# Altium schematic sheet BuckAndOutputCircuits. Page 2.SchDoc
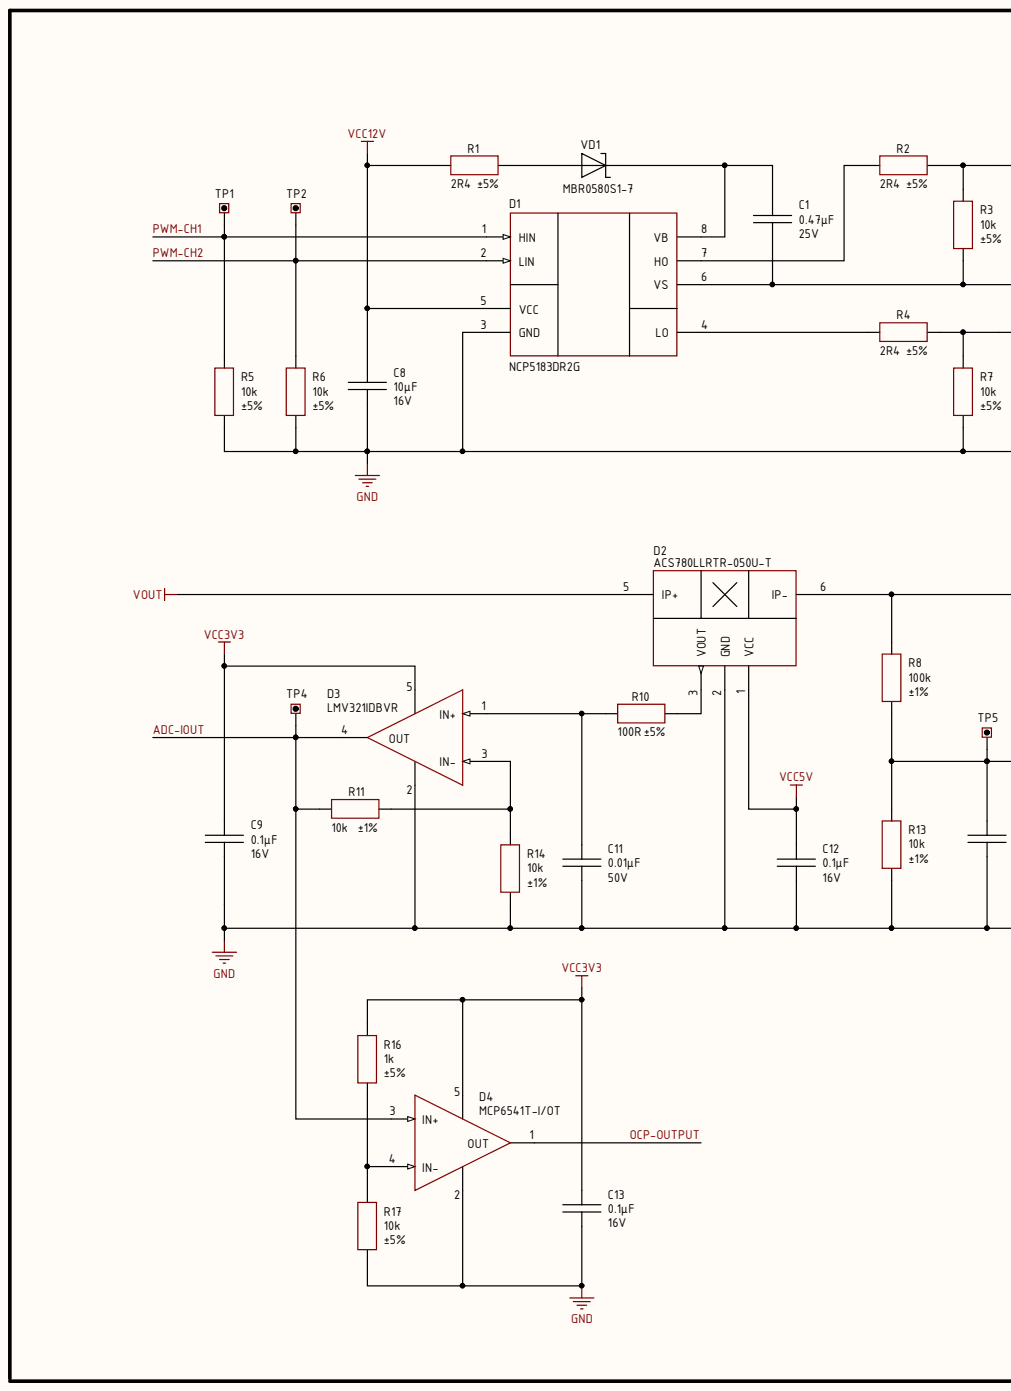
[diagram p0: BuckAndOutputCircuits. Page 2.SchDoc, left]
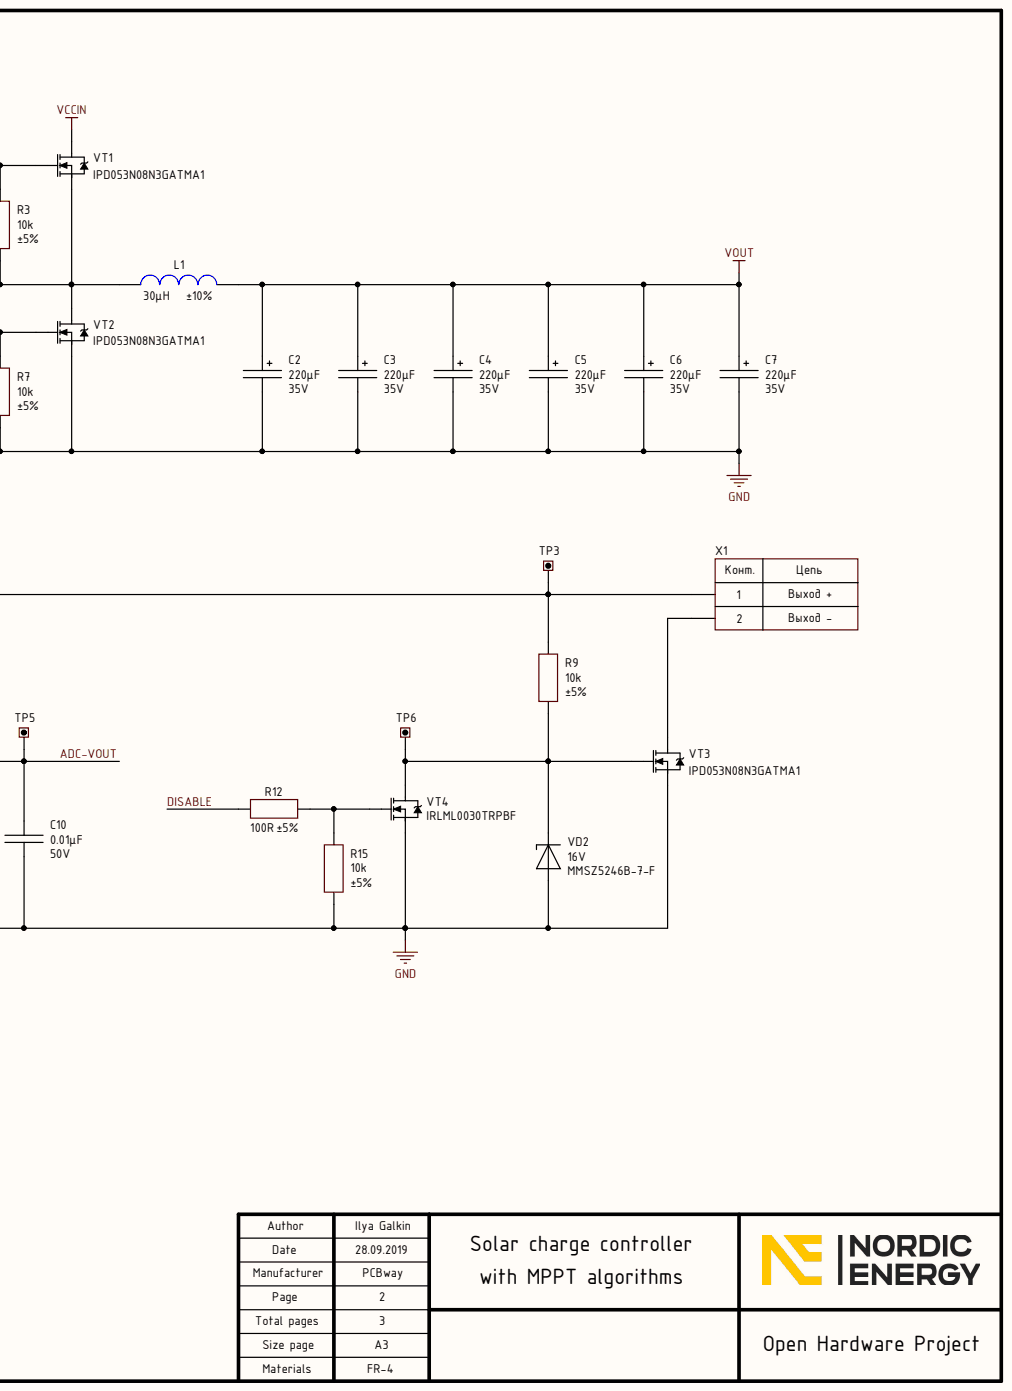
[diagram p1: BuckAndOutputCircuits. Page 2.SchDoc, right]

## Parts
C1  value 0.47μF  Voltage: 25V  [CAP: 0805]  (hidden comment: Ceramic capacitor, 0.47μF, X7R, ±10%, 25V, 0805, -55...+125°C)
C2  value 220μF  Voltage: 35V  [CAP: D6.3C2.5H11]  (hidden comment: Aluminium polymer capacitor, 220μF, 35V, ±20%, 30mOhm, 12x6.3x2.5 mm, -55...+105°C)
C3  value 220μF  Voltage: 35V  [CAP: D6.3C2.5H11]  (hidden comment: Aluminium polymer capacitor, 220μF, 35V, ±20%, 30mOhm, 12x6.3x2.5 mm, -55...+105°C)
C4  value 220μF  Voltage: 35V  [CAP: D6.3C2.5H11]  (hidden comment: Aluminium polymer capacitor, 220μF, 35V, ±20%, 30mOhm, 12x6.3x2.5 mm, -55...+105°C)
C5  value 220μF  Voltage: 35V  [CAP: D6.3C2.5H11]  (hidden comment: Aluminium polymer capacitor, 220μF, 35V, ±20%, 30mOhm, 12x6.3x2.5 mm, -55...+105°C)
C6  value 220μF  Voltage: 35V  [CAP: D6.3C2.5H11]  (hidden comment: Aluminium polymer capacitor, 220μF, 35V, ±20%, 30mOhm, 12x6.3x2.5 mm, -55...+105°C)
C7  value 220μF  Voltage: 35V  [CAP: D6.3C2.5H11]  (hidden comment: Aluminium polymer capacitor, 220μF, 35V, ±20%, 30mOhm, 12x6.3x2.5 mm, -55...+105°C)
C8  value 10μF  Voltage: 16V  [CAP: 0805]  (hidden comment: Ceramic capacitor, 10μF, X7R, ±10%, 16V, 0805, -55...+125°C)
C9  value 0.1μF  Voltage: 16V  [CAP: 0805]  (hidden comment: Ceramic capacitor, 0.1μF, X7R, ±10%, 16V, 0805, -55...+125°C)
C10  value 0.01μF  Voltage: 50V  [CAP: 0805]  (hidden comment: Ceramic capacitor, 0.01μF, X7R, ±10%, 50V, 0805, -55...+125°C)
C11  value 0.01μF  Voltage: 50V  [CAP: 0805]  (hidden comment: Ceramic capacitor, 0.01μF, X7R, ±10%, 50V, 0805, -55...+125°C)
C12  value 0.1μF  Voltage: 16V  [CAP: 0805]  (hidden comment: Ceramic capacitor, 0.1μF, X7R, ±10%, 16V, 0805, -55...+125°C)
C13  value 0.1μF  Voltage: 16V  [CAP: 0805]  (hidden comment: Ceramic capacitor, 0.1μF, X7R, ±10%, 16V, 0805, -55...+125°C)
D1  Half-bridge gate driver, 600V, 500kHz, ±4.3A, supply 9...18V, -40...+125°C, 8-SOIC  [8-SOIC]  (value not drawn)
D2  PartNumber: ACS780LLRTR-050U-T  [SENSOR: ACS781]  (hidden comment: IC current sensors, 50A, ±1.5%, 120 kHz, 60mV/A, -40...+150°C, 7-PSOF)
D3  IC op amplifier, Rail-to-Rail, 1 MHz, 2.7...5.5V, -40...+125°C, SOT23-5  [SOT23-5]  (value not drawn)
D4  IC comparator, Rail-to-Rail, 1.6...5.5V, -40...+85°C, SOT23-5  [SOT23-5]  (value not drawn)
L1  value 30μH  Tolerance: ±10%  [IND: R32.8/20.1/10.7 (L)]  (hidden comment: Fixed inductor, 30μH, ±10%, R32.8/20.1/10.7, Kool Mu, µ=125, 127 нГн/витков2)
R1  value 2R4  Tolerance: ±5%  [RES: 0805]  (hidden comment: Resistor film, 2R4, ±5%, 0805, 0.125W, -55...+125°C)
R2  value 2R4  Tolerance: ±5%  [RES: 0805]  (hidden comment: Resistor film, 2R4, ±5%, 0805, 0.125W, -55...+125°C)
R3  value 10k  Tolerance: ±5%  [RES: 0805]  (hidden comment: Resistor film, 10k, ±5%, 0805, 0.125W, -55...+125°C)
R4  value 2R4  Tolerance: ±5%  [RES: 0805]  (hidden comment: Resistor film, 2R4, ±5%, 0805, 0.125W, -55...+125°C)
R5  value 10k  Tolerance: ±5%  [RES: 0805]  (hidden comment: Resistor film, 10k, ±5%, 0805, 0.125W, -55...+125°C)
R6  value 10k  Tolerance: ±5%  [RES: 0805]  (hidden comment: Resistor film, 10k, ±5%, 0805, 0.125W, -55...+125°C)
R7  value 10k  Tolerance: ±5%  [RES: 0805]  (hidden comment: Resistor film, 10k, ±5%, 0805, 0.125W, -55...+125°C)
R8  value 100k  Tolerance: ±1%  [RES: 0805]  (hidden comment: Resistor film, 100k, ±1%, 0805, 0.125W, -55...+125°C)
R9  value 10k  Tolerance: ±5%  [RES: 0805]  (hidden comment: Resistor film, 10k, ±5%, 0805, 0.125W, -55...+125°C)
R10  value 100R  Tolerance: ±5%  [RES: 0805]  (hidden comment: Resistor film, 100R, ±5%, 0805, 0.125W, -55...+125°C)
R11  value 10k  Tolerance: ±1%  [RES: 0805]  (hidden comment: Resistor film, 10k, ±1%, 0805, 0.125W, -55...+125°C)
R12  value 100R  Tolerance: ±5%  [RES: 0805]  (hidden comment: Resistor film, 100R, ±5%, 0805, 0.125W, -55...+125°C)
R13  value 10k  Tolerance: ±1%  [RES: 0805]  (hidden comment: Resistor film, 10k, ±1%, 0805, 0.125W, -55...+125°C)
R14  value 10k  Tolerance: ±1%  [RES: 0805]  (hidden comment: Resistor film, 10k, ±1%, 0805, 0.125W, -55...+125°C)
R15  value 10k  Tolerance: ±5%  [RES: 0805]  (hidden comment: Resistor film, 10k, ±5%, 0805, 0.125W, -55...+125°C)
R16  value 1k  Tolerance: ±5%  [RES: 0805]  (hidden comment: Resistor film, 1k, ±5%, 0805, 0.125W, -55...+125°C)
R17  value 10k  Tolerance: ±5%  [RES: 0805]  (hidden comment: Resistor film, 10k, ±5%, 0805, 0.125W, -55...+125°C)
TP1  Test point, Keystone, 5001  [CON: 5001 (Keystone)]  (value not drawn)
TP2  Test point, Keystone, 5001  [CON: 5001 (Keystone)]  (value not drawn)
TP3  Test point, Keystone, 5001  [CON: 5001 (Keystone)]  (value not drawn)
TP4  Test point, Keystone, 5001  [CON: 5004 (Keystone)]  (value not drawn)
TP5  Test point, Keystone, 5001  [CON: 5004 (Keystone)]  (value not drawn)
TP6  Test point, Keystone, 5001  [CON: 5001 (Keystone)]  (value not drawn)
VD1  PartNumber: MBR0580S1-7  [DIODE: SOD123]  (hidden comment: Schottky diode, 80V, 500mA, 1V, 15pF, SOD-123, -55...+150°C)
VD2  PartNumber: MMSZ5246B-7-F  Voltage: 16V  [DIODE: SOD123]  (hidden comment: Zener diode, 16V, 500mW, 0.9V, 17Ohm, SOD-123, -65...+150°C)
VT1  PartNumber: IPD053N08N3GATMA1  [DPAK]  (hidden comment: MOSFET N-channel transistor, 80V, 90A, 69nC, 150W, 5.3mOhm, 4750pF, Dpak, -55...+150°C)
VT2  PartNumber: IPD053N08N3GATMA1  [DPAK]  (hidden comment: MOSFET N-channel transistor, 80V, 90A, 69nC, 150W, 5.3mOhm, 4750pF, Dpak, -55...+150°C)
VT3  PartNumber: IPD053N08N3GATMA1  [DPAK]  (hidden comment: MOSFET N-channel transistor, 80V, 90A, 69nC, 150W, 5.3mOhm, 4750pF, Dpak, -55...+150°C)
VT4  PartNumber: IRLML0030TRPBF  [SOT23]  (hidden comment: MOSFET N-channel transistor, 30V, 5.3A, 2.6nC, 2.5W, 27mOhm, 382pF, SOT-23, -55...+150°C)
X1  Connector, 2pin, 9.53mm, 300VAC, 25A  [CON: 6PCV-02-006]  (value not drawn)

## Nets
GND: C2.2 C3.2 C4.2 C5.2 C6.2 C7.2 C8.1 C9.1 C10.1 C11.1 C12.1 C13.1 D1.3(GND) D2.2(GND) D3.2 D4.2 R5.2 R6.2 R7.2 R13.2 R14.2 R15.2 R17.2 VD2.1 VT2.2 VT3.2 VT4.2
VCC3V3: C9.2 C13.2 D3.5 D4.5 R16.1
VCC5V: C12.2 D2.1(VCC)
VCC12V: C8.2 D1.5(VCC) R1.1
VCCIN: VT1.3
VOUT: C2.1 C3.1 C4.1 C5.1 C6.1 C7.1 D2.5(IP+) L1.1
ADC-IOUT: D3.4(OUT) D4.3(IN+) R11.1 TP4.1
ADC-VOUT: C10.2 R8.2 R13.1 TP5.1
DISABLE: R12.1
OCP-OUTPUT: D4.1(OUT)
PWM-CH1: D1.1(HIN) R5.1 TP1.1
PWM-CH2: D1.2(LIN) R6.1 TP2.1
NetC1_1: C1.1 D1.6(VS) L1.2 R3.2 VT1.2 VT2.3
NetC1_2: C1.2 D1.8(VB) VD1.2
NetC11_2: C11.2 D3.1(IN+) R10.1
NetD1_4: D1.4(LO) R4.1
NetD1_7: D1.7(HO) R2.1
NetD2_3: D2.3(VOUT) R10.2
NetD2_6: D2.6(IP-) R8.1 R9.1 TP3.1 X1.1
NetD3_3: D3.3(IN-) R11.2 R14.1
NetD4_4: D4.4(IN-) R16.2 R17.1
NetR1_2: R1.2 VD1.1
NetR2_2: R2.2 R3.1 VT1.1
NetR4_2: R4.2 R7.1 VT2.1
NetR9_2: R9.2 TP6.1 VD2.2 VT3.1 VT4.3
NetR12_2: R12.2 R15.1 VT4.1
NetVT3_3: VT3.3 X1.2

## Library data of the parts
C1 = Ceramic_0805: Manufacturer: Yageo; PartNumber: CC0805KKX7R8BB474; Component Kind: Standard; HelpURL: https://www.digikey.com/product-detail/en/yageo/CC0805KKX7R8BB474/311-1364-1-ND/2103148; Library Name: Capacitor.IntLib; Package: 0805; Temperature: -55...+125°C; Tolerance: ±10%; TypeCapacitor: Ceramic capacitor; TypeDielectric: X7R; ValueManufacturerPartNumber: Ceramic capacitor, 0.47μF, X7R, ±10%, 25V, 0805, -55...+125°C
C2-C7 = Aluminium: Manufacturer: Aihua Group; PartNumber: SPZ1VM221E12O00; Component Kind: Standard; HelpURL: https://lcsc.com/product-detail/Solid-Polymer-Electrolytic-Capacitor_220uF-35V_C171433.html; Impedance: 30mOhm; Library Name: Capacitor.IntLib; Package: 12x6.3x2.5 mm; Temperature: -55...+105°C; Tolerance: ±20%; TypeCapacitor: Aluminium polymer capacitor; ValueManufacturerPartNumber: Aluminium polymer capacitor, 220μF, 35V, ±20%, 30mOhm, 12x6.3x2.5 mm, -55...+105°C
C8 = Ceramic_0805: Manufacturer: Samsung; PartNumber: CL21B106KOQNNNG; Component Kind: Standard; HelpURL: https://www.digikey.com/product-detail/en/samsung-electro-mechanics/CL21B106KOQNNNG/1276-6472-1-ND/5958100; Library Name: Capacitor.IntLib; Package: 0805; Temperature: -55...+125°C; Tolerance: ±10%; TypeCapacitor: Ceramic capacitor; TypeDielectric: X7R; ValueManufacturerPartNumber: Ceramic capacitor, 10μF, X7R, ±10%, 16V, 0805, -55...+125°C
C9 C12 C13 = Ceramic_0805: Manufacturer: Yageo; PartNumber: CC0805KRX7R7BB104; Component Kind: Standard; HelpURL: https://www.digikey.com/product-detail/en/yageo/CC0805KRX7R7BB104/311-1142-1-ND/303052; Library Name: Capacitor.IntLib; Package: 0805; Temperature: -55...+125°C; Tolerance: ±10%; TypeCapacitor: Ceramic capacitor; TypeDielectric: X7R; ValueManufacturerPartNumber: Ceramic capacitor, 0.1μF, X7R, ±10%, 16V, 0805, -55...+125°C
C10 C11 = Ceramic_0805: Manufacturer: Yageo; PartNumber: CC0805KRX7R9BB103; Component Kind: Standard; HelpURL: https://www.digikey.com/product-detail/en/yageo/CC0805KRX7R9BB103/311-1136-1-ND/303046; Library Name: Capacitor.IntLib; Package: 0805; Temperature: -55...+125°C; Tolerance: ±10%; TypeCapacitor: Ceramic capacitor; TypeDielectric: X7R; ValueManufacturerPartNumber: Ceramic capacitor, 0.01μF, X7R, ±10%, 50V, 0805, -55...+125°C
D1 = NCP5183DR2G: Gate driver; Manufacturer: ON Semiconductor; Component Kind: Standard; ComponentType: Half-bridge gate driver; CurrentOutput: ±4.3A; Frequency: 500kHz; HelpURL: https://www.digikey.com/product-detail/en/on-semiconductor/NCP5183DR2G/NCP5183DR2GOSCT-ND/7066807; Library Name: IC. Gate driver.IntLib; Package: 8-SOIC; PartNumber: NCP5183DR2G; Temperature: -40...+125°C; ValueManufacturerPartNumber: Half-bridge gate driver, 600V, 500kHz, ±4.3A, supply 9...18V, -40...+125°C, 8-SOIC; VoltageSupply: supply 9...18V; VoltageWork: 600V
D2 = ACS780: Current sensors; Manufacturer: Allegro MicroSystems; GainBandwidth: 120 kHz; Supply: 4.5...5.5V; Accuracy: ±1.5%; Component Kind: Standard; ComponentType: IC current sensors; HelpURL: https://www.digikey.com/product-detail/en/allegro-microsystems-llc/ACS780LLRTR-050U-T/620-1818-1-ND/6189107; Library Name: IC. Sensor.IntLib; Package: 7-PSOF; Sensing: 50A; Sensitivity: 60mV/A; Temperature: -40...+150°C; ValueManufacturerPartNumber: IC current sensors, 50A, ±1.5%, 120 kHz, 60mV/A, -40...+150°C, 7-PSOF
D3 = LMV321IDBVR: OP amplifier; Manufacturer: Texas Instruments; GainBandwidth: 1 MHz; Component Kind: Standard; ComponentType: IC op amplifier; HelpURL: https://www.digikey.com/product-detail/en/texas-instruments/LMV321IDBVR/296-9567-1-ND/379849; Library Name: IC. Amplifier.IntLib; OutputType: Rail-to-Rail; Package: SOT23-5; PartNumber: LMV321IDBVR; Supply: 2.7...5.5V; Temperature: -40...+125°C; ValueManufacturerPartNumber: IC op amplifier, Rail-to-Rail, 1 MHz, 2.7...5.5V, -40...+125°C, SOT23-5
D4 = MCP6541T-I/OT: Comparator; Manufacturer: Microchip Technology; Component Kind: Standard; ComponentType: IC comparator; HelpURL: https://www.digikey.com/product-detail/en/microchip-technology/MCP6541T-I-OT/MCP6541T-I-OTCT-ND/3622387; Library Name: IC. Comparator.IntLib; OutputType: Rail-to-Rail; Package: SOT23-5; PartNumber: MCP6541T-I/OT; Supply: 1.6...5.5V; Temperature: -40...+85°C; ValueManufacturerPartNumber: IC comparator, Rail-to-Rail, 1.6...5.5V, -40...+85°C, SOT23-5
L1 = Inductor_R32.8/20.1/10.7: Manufacturer: Magnetics; PartNumber: 0077548A7; TypeMatiriel: Kool Mu; AL: 127 нГн/витков2; Component Kind: Standard; HelpURL: http://ferrite.ru/warehouse/r-32-820-110-7-77548-a7.html; Library Name: Inductor.IntLib; MaterialPermeability: µ=125; Package: R32.8/20.1/10.7; TypeInductor: Fixed inductor; ValueManufacturerPartNumber: Fixed inductor, 30μH, ±10%, R32.8/20.1/10.7, Kool Mu, µ=125, 127 нГн/витков2
R1 R2 R4 = Resistor_0805: Manufacturer: Yageo; PartNumber: RC0805JR-072R4L; Component Kind: Standard; HelpURL: https://www.digikey.com/product-detail/en/yageo/RC0805JR-072R4L/311-2.4ARCT-ND/731220; Library Name: Resistor.IntLib; Package: 0805; Power: 0.125W; Temperature: -55...+125°C; TypeResistor: Resistor film; ValueManufacturerPartNumber: Resistor film, 2R4, ±5%, 0805, 0.125W, -55...+125°C
R3 R5-R7 R9 R15 R17 = Resistor_0805: Manufacturer: Yageo; PartNumber: RC0805JR-0710KL; Component Kind: Standard; HelpURL: https://www.digikey.com/product-detail/en/yageo/RC0805JR-0710KL/311-10KARCT-ND/731188; Library Name: Resistor.IntLib; Package: 0805; Power: 0.125W; Temperature: -55...+125°C; TypeResistor: Resistor film; ValueManufacturerPartNumber: Resistor film, 10k, ±5%, 0805, 0.125W, -55...+125°C
R8 = Resistor_0805: Manufacturer: Yageo; PartNumber: RC0805FR-07100KL; Component Kind: Standard; HelpURL: https://www.digikey.com/product-detail/en/yageo/RC0805FR-07100KL/311-100KCRCT-ND/730491; Library Name: Resistor.IntLib; Package: 0805; Power: 0.125W; Temperature: -55...+125°C; TypeResistor: Resistor film; ValueManufacturerPartNumber: Resistor film, 100k, ±1%, 0805, 0.125W, -55...+125°C
R10 R12 = Resistor_0805: Manufacturer: Yageo; PartNumber: RC0805JR-07100RL; Component Kind: Standard; HelpURL: https://www.digikey.com/product-detail/en/yageo/RC0805JR-07100RL/311-100ARCT-ND/731185; Library Name: Resistor.IntLib; Package: 0805; Power: 0.125W; Temperature: -55...+125°C; TypeResistor: Resistor film; ValueManufacturerPartNumber: Resistor film, 100R, ±5%, 0805, 0.125W, -55...+125°C
R11 R13 R14 = Resistor_0805: Manufacturer: Yageo; PartNumber: RC0805FR-0710KL; Component Kind: Standard; HelpURL: https://www.digikey.com/product-detail/en/yageo/RC0805FR-0710KL/311-10.0KCRCT-ND/730482; Library Name: Resistor.IntLib; Package: 0805; Power: 0.125W; Temperature: -55...+125°C; TypeResistor: Resistor film; ValueManufacturerPartNumber: Resistor film, 10k, ±1%, 0805, 0.125W, -55...+125°C
R16 = Resistor_0805: Manufacturer: Yageo; PartNumber: RC0805JR-071KL; Component Kind: Standard; HelpURL: https://www.digikey.com/product-detail/en/yageo/RC0805JR-071KL/311-1.0KARCT-ND/731165; Library Name: Resistor.IntLib; Package: 0805; Power: 0.125W; Temperature: -55...+125°C; TypeResistor: Resistor film; ValueManufacturerPartNumber: Resistor film, 1k, ±5%, 0805, 0.125W, -55...+125°C
TP1-TP6 = Connector_Test_Point: PartNumber: 5001; Component Kind: Standard; HelpURL: https://www.digikey.com/product-detail/en/keystone-electronics/5001/36-5001-ND/255327; Library Name: Connector.IntLib; Manufacturer: Keystone; TypeConnector: Test point; ValueManufacturerPartNumber: Test point, Keystone, 5001
VD1 = Schottky_single: Manufacturer: Diodes Incorporated; Component Kind: Standard; Current: 500mA; HelpURL: https://www.digikey.com/product-detail/en/diodes-incorporated/MBR0580S1-7/MBR0580S1-7DICT-ND/5080371; Library Name: Diode.IntLib; Package: SOD-123; RecoveryTime: 15pF; Temperature: -55...+150°C; TypeDiode: Schottky diode; ValueManufacturerPartNumber: Schottky diode, 80V, 500mA, 1V, 15pF, SOD-123, -55...+150°C; Voltage: 80V; VoltageDrop: 1V
VD2 = Zener_single: Manufacturer: Diodes Incorporated; Component Kind: Standard; Current: 500mW; HelpURL: https://www.digikey.com/product-detail/en/diodes-incorporated/MMSZ5246B-7-F/MMSZ5246B-FDICT-ND/717912; Library Name: Diode.IntLib; Package: SOD-123; RecoveryTime: 17Ohm; Temperature: -65...+150°C; TypeDiode: Zener diode; ValueManufacturerPartNumber: Zener diode, 16V, 500mW, 0.9V, 17Ohm, SOD-123, -65...+150°C; VoltageDrop: 0.9V
VT1-VT4 = MOSFET_N_132: Manufacturer: Infineon Technologies; Component Kind: Standard; Library Name: Transistor.IntLib; Temperature: -55...+150°C; TypeTransistor: MOSFET N-channel transistor
  VT1-VT3: CurrentDS: 90A; GateCharge: 69nC; HelpURL: https://www.digikey.com/product-detail/en/infineon-technologies/IPD053N08N3GATMA1/IPD053N08N3GATMA1CT-ND/5353500; InputCapacitor: 4750pF; MaxPower: 150W; Package: Dpak; RDS: 5.3mOhm; ValueManufacturerPartNumber: MOSFET N-channel transistor, 80V, 90A, 69nC, 150W, 5.3mOhm, 4750pF, Dpak, -55...+150°C; VoltageDS: 80V
  VT4: CurrentDS: 5.3A; GateCharge: 2.6nC; HelpURL: https://www.digikey.com/product-detail/en/infineon-technologies/IRLML0030TRPBF/IRLML0030TRPBFCT-ND/2202231; InputCapacitor: 382pF; MaxPower: 2.5W; Package: SOT-23; RDS: 27mOhm; ValueManufacturerPartNumber: MOSFET N-channel transistor, 30V, 5.3A, 2.6nC, 2.5W, 27mOhm, 382pF, SOT-23, -55...+150°C; VoltageDS: 30V
X1 = Connector_6PCV-02: Manufacturer: TE Connectivity; PartNumber: 6PCV-02-006; CommentText: "Solar panel"; Component Kind: Standard; CurrentMax: 25A; HelpURL: https://www.digikey.com/products/en?keywords=6PCV-02-006; Library Name: Connector.IntLib; Multichannel: 2pin; Pitch: 9.53mm; TypeConnector: Connector; ValueManufacturerPartNumber: Connector, 2pin, 9.53mm, 300VAC, 25A; VoltageMax: 300VAC
